# Altium schematic sheet ArcadeRpiSmartShield 33 MCU.SchDoc
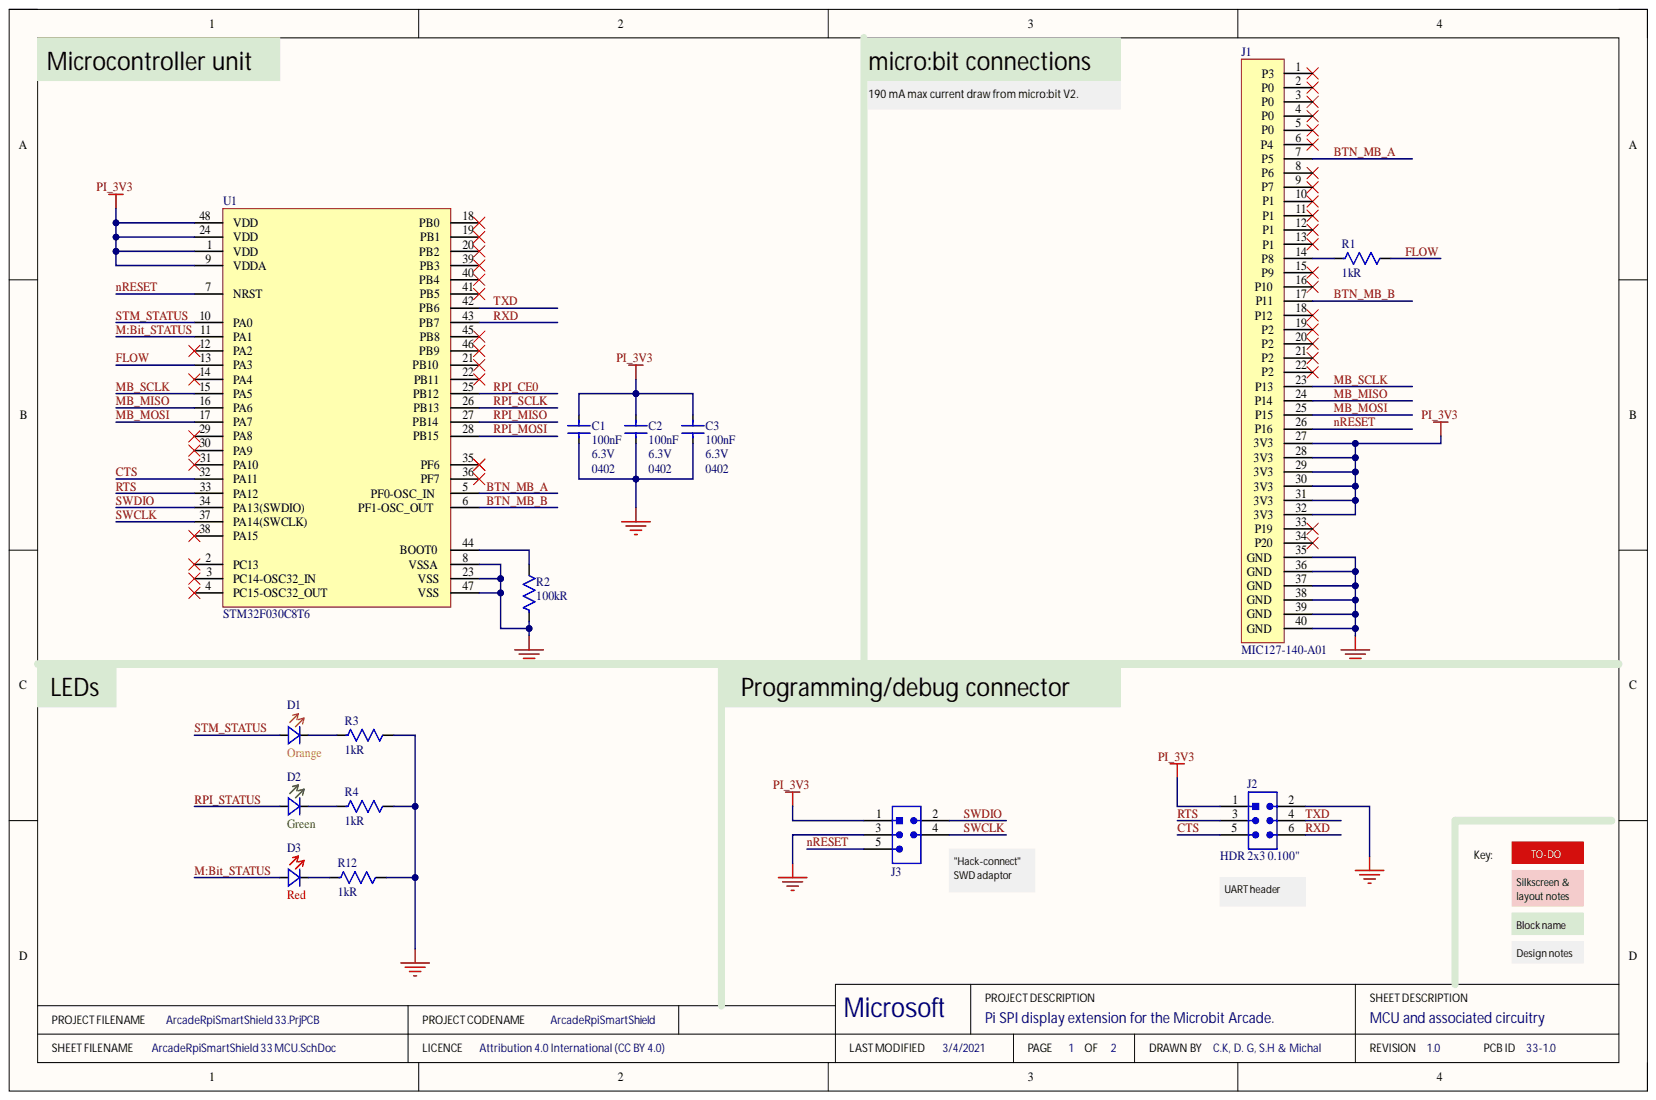
[diagram p0: ArcadeRpiSmartShield 33 MCU.SchDoc]

## Parts
C1  Capacitance: 100nF  Case Code (Imperial): 0402  Voltage Rating: 6.3V  [C0402_0.55MM - without outline]  (hidden comment: CL05B104KQ5NNNC)
C2  Capacitance: 100nF  Case Code (Imperial): 0402  Voltage Rating: 6.3V  [C0402_0.55MM - without outline]  (hidden comment: CL05B104KQ5NNNC)
C3  Capacitance: 100nF  Case Code (Imperial): 0402  Voltage Rating: 6.3V  [C0402_0.55MM - without outline]  (hidden comment: CL05B104KQ5NNNC)
D1  Colour: Orange  [Rohm SML-D12 Orange - without outline]  (hidden comment: SML-D12D)
D2  Colour: Green  [Rohm SML-D12 Green - without outline]  (hidden comment: SML-D12P)
D3  Colour: Red  [Rohm SML-D12 Red - without outline]  (hidden comment: SML-D12U)
J1  Right Angle 1x40p 1.27 mm pitch edge connector for the micro:bit  [MIC127-140-A01]  (value not drawn)
J2  HDR 2x3 0.100"  [PREC003DFAN-RC]
J3  Hack Connect Mini 1.27 mm pitch 2-row  [HACK_CONNECT_MINI_5_X_1_27]  (value not drawn)  (no BOM)
R1  Resistance: 1kR  [R0402 - Without outline]  (hidden comment: RC0402FR-071KL)
R2  Resistance: 100kR  [R0402 - Without outline]  (hidden comment: RC0402FR-07100KL)
R3  Resistance: 1kR  [R0402 - Without outline]  (hidden comment: RC0402FR-071KL)
R4  Resistance: 1kR  [R0402 - Without outline]  (hidden comment: RC0402FR-071KL)
R12  Resistance: 1kR  [R0402 - Without outline]  (hidden comment: RC0402FR-071KL)
U1  STM32F030C8T6  [48-LQFP (7x7)]

## Nets
GND: C1.1 C2.1 C3.1 J1.35(GND) J1.36(GND) J1.37(GND) J1.38(GND) J1.39(GND) J1.40(GND) J2.2 J3.3 R2.1 R3.1 R4.1 R12.1 U1.8(VSSA) U1.23(VSS) U1.47(VSS)
PI_3V3: C1.2 C2.2 C3.2 J1.27(3V3) J1.28(3V3) J1.29(3V3) J1.30(3V3) J1.31(3V3) J1.32(3V3) J2.1 J3.1 U1.1(VDD) U1.9(VDDA) U1.24(VDD) U1.48(VDD)
BTN_MB_A: J1.7(P5) U1.5(PF0-OSC_IN)
BTN_MB_B: J1.17(P11) U1.6(PF1-OSC_OUT)
CTS: J2.5 U1.32(PA11)
FLOW: R1.1 U1.13(PA3)
M:Bit_STATUS: D3.2 U1.11(PA1)
MB_MISO: J1.24(P14) U1.16(PA6)
MB_MOSI: J1.25(P15) U1.17(PA7)
MB_SCLK: J1.23(P13) U1.15(PA5)
nRESET: J1.26(P16) J3.5 U1.7(NRST)
RPI_CE0: U1.25(PB12)
RPI_MISO: U1.27(PB14)
RPI_MOSI: U1.28(PB15)
RPI_SCLK: U1.26(PB13)
RPI_STATUS: D2.2
RTS: J2.3 U1.33(PA12)
RXD: J2.6 U1.43(PB7)
STM_STATUS: D1.2 U1.10(PA0)
SWCLK: J3.4 U1.37(PA14(SWCLK))
SWDIO: J3.2 U1.34(PA13(SWDIO))
TXD: J2.4 U1.42(PB6)
NetD1_1: D1.1 R3.2
NetD2_1: D2.1 R4.2
NetD3_1: D3.1 R12.2
NetJ1_14: J1.14(P8) R1.2
NetR2_2: R2.2 U1.44(BOOT0)

Not connected: J1 1(P3) 2(P0) 3(P0) 4(P0) 5(P0) 6(P4) 8(P6) 9(P7) 10(P1) 11(P1) 12(P1) 13(P1) 15(P9) 16(P10) 18(P12) 19(P2) 20(P2) 21(P2) 22(P2) 33(P19) 34(P20); U1 2(PC13) 3(PC14-OSC32_IN) 4(PC15-OSC32_OUT) 12(PA2) 14(PA4) 18(PB0) 19(PB1) 20(PB2) 21(PB10) 22(PB11) 29(PA8) 30(PA9) 31(PA10) 35(PF6) 36(PF7) 38(PA15) 39(PB3) 40(PB4) 41(PB5) 45(PB8) 46(PB9)

## Library data of the parts
C1-C3 = CL05B104KQ5NNNC: CAP CER 0.1UF 6.3V X7R 0402; Manufacturer: Samsung; Tolerance: 10%; Case Code (Metric): 1005; Case/Package: 0402; Category: Capacitors; Component Kind: Standard; ComponentLink1Description: Online datasheet; ComponentLink1URL: https://datasheet.ciiva.com/25709/cl05b104kq5nnnc-25709268.pdf; Depth: 0.5mm; Dielectric: X7R; Height: 0.5mm; Lead Free: Lead Free; Length: 1mm; Library Name: Samsung.SchLib; Manufacturer Part Number: CL05B104KQ5NNNC; Max Operating Temperature: 125°C; Min Operating Temperature: -55°C; Mount: Surface Mount; Packaging: Tape and Reel; Pricing 1: 1=0.10000, 10=0.02500, 50=0.01380, 100=0.01130, 500=0.00804, 1000=0.00632, 2500=0.00575, 5000=0.00529 (USD); Pricing 2: 1=0.10000, 10=0.02800, 100=0.01200, 500=0.00800, 1000=0.00600, 2500=0.00600 (USD); Radiation Hardening: No; RoHS: Yes; Stock 1: 259020; Stock 2: 20000; Supplier 1: Digi-Key; Supplier 2: Mouser; Supplier Part Number 1: 1276-1511-1-ND; Supplier Part Number 2: 187-CL05B104KQ5NNNC; Thickness: 0.022inch; Voltage Rating (DC): 6.3V; Width: 0.02inch; Checked By: ?; Created By: D. Gakure
D1 = SML-D12D: 1.6 x 0.8 x 0.55mm (0603) LED 605nm Orange; Manufacturer: Rohm Semiconductor; Manufacturer Part Number: SML-D12D8WT86; Current - Test: 20mA; Lens Style/Size: Rectangle with Flat Top, 1.2mm x 0.8mm; Lens Type: Diffused, White; Millicandela Rating: 100mcd; Voltage - Forward (Vf) (Typ): 2.2V; Category: Optoelectronics; Component Kind: Standard; ComponentLink1Description: Online datasheet; ComponentLink1URL: https://d1d2qsbl8m0m72.cloudfront.net/en/products/databook/datasheet/opto/led/chip_mono/sml-d12x8_d13x8-e.pdf; Height: 0.55mm; Library Name: Rohm.SchLib; Luminous Flux @ Current - Test: -; Mounting Type: Surface Mount; Package / Case: 0603 (1608 Metric); Packaging: Cut Tape (CT); Pricing 1: 1=0.38, 10=0.279, 25=0.2232, 100=0.1478, 250=0.1046, 500=0.08926, 1000=0.06974 (GBP); RoHS: RoHS Compliant; Size / Dimension: 1.60mm L x 0.80mm W; Stock 1: 1719; Supplier 1: Digi-Key; Supplier Part Number 1: 511-1577-1-ND; Viewing Angle: -; Wavelength - Dominant: 605nm; Wavelength - Peak: -
D2 = SML-D12P: 1.6 x 0.8 x 0.55mm (0603) LED 560nm Green; Manufacturer: Rohm Semiconductor; Manufacturer Part Number: SML-D12P8WT86; Current - Test: 20mA; Lens Style/Size: Rectangle with Flat Top, 1.2mm x 0.8mm; Lens Type: Diffused, White; Millicandela Rating: 6.3mcd; Voltage - Forward (Vf) (Typ): 2.2V; Category: Optoelectronics; Component Kind: Standard; ComponentLink1Description: Online datasheet; ComponentLink1URL: https://d1d2qsbl8m0m72.cloudfront.net/en/products/databook/datasheet/opto/led/chip_mono/sml-d12x8_d13x8-e.pdf; Height: 0.55mm; Library Name: Rohm.SchLib; Luminous Flux @ Current - Test: -; Mounting Type: Surface Mount; Package / Case: 0603 (1608 Metric); Packaging: Cut Tape (CT); Pricing 1: 1=0.38, 10=0.279, 25=0.2232, 100=0.1478, 250=0.1046, 500=0.08926, 1000=0.06974 (GBP); RoHS: RoHS Compliant; Size / Dimension: 1.60mm L x 0.80mm W; Stock 1: 19305; Supplier 1: Digi-Key; Supplier Part Number 1: 511-1579-1-ND; Viewing Angle: -; Wavelength - Dominant: 560nm; Wavelength - Peak: -
D3 = SML-D12U: 1.6 x 0.8 x 0.55mm (0603) LED 620nm Red; Manufacturer: Rohm Semiconductor; Manufacturer Part Number: SML-D12U8WT86; Current - Test: 20mA; Lens Style/Size: Rectangle with Flat Top, 1.2mm x 0.8mm; Lens Type: Diffused, White; Millicandela Rating: 63mcd; Voltage - Forward (Vf) (Typ): 2.2V; Category: Optoelectronics; Component Kind: Standard; ComponentLink1Description: Online datasheet; ComponentLink1URL: https://d1d2qsbl8m0m72.cloudfront.net/en/products/databook/datasheet/opto/led/chip_mono/sml-d12x8_d13x8-e.pdf; Height: 0.55mm; Library Name: Rohm.SchLib; Luminous Flux @ Current - Test: -; Mounting Type: Surface Mount; Package / Case: 0603 (1608 Metric); Packaging: Cut Tape (CT); Pricing 1: 1=0.38, 10=0.279, 25=0.2232, 100=0.1478, 250=0.1046, 500=0.08926, 1000=0.06974 (GBP); RoHS: RoHS Compliant; Size / Dimension: 1.60mm L x 0.80mm W; Stock 1: 5722; Supplier 1: Digi-Key; Supplier 2: Farnell; Supplier Part Number 1: 511-1580-1-ND; Supplier Part Number 2: 1685094; Viewing Angle: -; Wavelength - Dominant: 620nm; Wavelength - Peak: -
J1 = MIC127-140-A01: "Right Angle" 1x40p 1.27mm Dongguan Yuliang BBC micro:bit edge connector; Component Kind: Standard; ComponentLink1Description: Yuliang drawing; ComponentLink1URL: http://www.dgyuliang.net/d/file/Produtcs/Customized%20Connector/MICRO%20BIT%20Connector/0d43030af84ade6fc3f00e242079c055.pdf; Library Name: Yuliang.SchLib; Manufacturer: Dongguan Yuliang; Manufacturer Part Number: MIC127-140-A01
J2 = PREC003DFAN-RC: CONN HEADER VERT 6POS 2.54MM; Manufacturer: Sullins; Connector Type: Male Pin; Number of Positions: 6; Pitch: 0.1inch; Category: Connectors; Color: Black; Component Kind: Standard; ComponentLink1Description: Online datasheet; ComponentLink1URL: https://datasheet.ciiva.com/741/xrxczzzdxxn-rc-11636-b-741002.pdf; Contact Mating Length: 0.318inch; Contact Plating: Tin; Contact Type: Male Pin; Library Name: SullinsConnectorSolutions.SchLib; Manufacturer Part Number: PREC003DFAN-RC; Mount: Through Hole; Number of Rows: 2; Package Quantity: 1; Packaging: Bulk; Pricing 1: 1=0.16000, 10=0.15100, 25=0.12920, 50=0.11280, 100=0.10480, 250=0.09272, 500=0.08870 (USD); RoHS: Yes; RoHS Compliant: Yes; Row Spacing: 0.1inch; Stock 1: 1243; Supplier 1: Digi-Key; Supplier Part Number 1: S2212EC-03-ND; Termination: Solder; Checked By: ?; Created By: D. Gakure
J3 = HACK_CONNECT_MINI: Description: Hack Connect Mini 1.27 mm pitch 2-row; Library Name: generic-con.SchLib
R1 R3 R4 R12 = RC0402FR-071KL: RES SMD 1K OHM 1% 1/16W 0402; Manufacturer: Yageo; Power Rating: 62.5mW; Temperature Coefficient: 100ppm/°C; Voltage Rating: 50V; Case Code (Imperial): 0402; Case Code (Metric): 1005; Case/Package: 0402; Category: Resistors; Component Kind: Standard; ComponentLink2Description: Online datasheet; ComponentLink2URL: https://datasheet.ciiva.com/12666/pyu-rc0402-51-rohs-l-6-r-12666741.pdf; Depth: 0.5mm; Height: 0.32mm; Lead Free: Lead Free; Length: 1mm; Library Name: Yageo.SchLib; Manufacturer Part Number: RC0402FR-071KL; Max Operating Temperature: 155°C; Military Standard: Not; Min Operating Temperature: -55°C; Number of Pins: 2; Packaging: Tape and Reel; Pricing 1: 1=0.10000, 10=0.01400, 100=0.00580, 1000=0.00262, 2500=0.00228, 5000=0.00188 (USD); Pricing 2: 1=0.10000, 10=0.01200, 100=0.00400, 1000=0.00400, 2500=0.00400, 10000=0.00300 (USD); Pricing 3: 1=0.08130, 25=0.01210, 100=0.01120, 250=0.01030, 500=0.00900 (GBP); REACH SVHC: Unknown; Resistor Type: Thick Film; RoHS: Yes; Stock 1: 37591306; Stock 2: 11912978; Stock 3: 29900; Supplier 1: Digi-Key; Supplier 2: Mouser; Supplier 3: Farnell; Supplier Part Number 1: 311-1.00KLRDKR-ND; Supplier Part Number 2: 603-RC0402FR-071KL; Supplier Part Number 3: 2132441; Termination: SMD/SMT; Tolerance: 1%; Voltage Rating (DC): 50V; Width: 0.5mm; Checked: ?; Created: D.G
R2 = RC0402FR-07100KL: RES SMD 100K OHM 1% 1/16W 0402; Manufacturer: Yageo; Max Power Dissipation: 63mW; Temperature Coefficient: 100ppm/°C; Voltage Rating: 50V; Case Code (Imperial): 0402; Case Code (Metric): 1005; Case/Package: 0402; Category: Resistors; Component Kind: Standard; ComponentLink2Description: Online datasheet; ComponentLink2URL: https://datasheet.ciiva.com/2453/pyu-r-int-thick-7-2453361.pdf; Depth: 0.5mm; Features: Moisture Resistant; Height: 0.016inch; Lead Free: Lead Free; Length: 0.039inch; Library Name: Yageo.SchLib; Manufacturer Part Number: RC0402FR-07100KL; Max Operating Temperature: 155°C; Military Standard: Not; Min Operating Temperature: -55°C; Number of Terminations: 2; Package Quantity: 1; Packaging: Tape and Reel; Power Rating: 63mW; Pricing 1: 1=0.10000, 10=0.01400, 100=0.00580, 1000=0.00262, 2500=0.00228, 5000=0.00188 (USD); Pricing 2: 1=0.10000, 10=0.01200, 100=0.00400, 1000=0.00400, 2500=0.00400, 10000=0.00300 (USD); Pricing 3: 1=0.10200, 25=0.01220, 100=0.01200, 250=0.01020, 500=0.00890 (GBP); REACH SVHC: No SVHC; Resistor Type: Thick Film; RoHS: Yes; Stock 1: 5819191; Stock 2: 4458847; Stock 3: 19392; Supplier 1: Digi-Key; Supplier 2: Mouser; Supplier 3: Farnell; Supplier Part Number 1: 311-100KLRCT-ND; Supplier Part Number 2: 603-RC0402FR-07100KL; Supplier Part Number 3: 2131798; Termination: SMD/SMT; Tolerance: 1%; Width: 0.02inch; Working Voltage: 50V; Checked By: ?; Created By: DG
U1 = STM32F030C8T6: MCU 32-bit STM32F ARM Cortex M0 RISC 64KB Flash 2.5V/3.3V 48-Pin LQFP Tray; Manufacturer: STMicroelectronics; Data Bus Width: 32b; Frequency: 48MHz; Interface: I2C,SPI,UART,USART; Max Power Dissipation: 364mW; Max Supply Voltage: 3.6V; Min Supply Voltage: 2.4V; Number of ADC Channels: 12; Number of I2C Channels: 2; Number of I/Os: 39; Number of PWM Channels: 6; Number of Timers/Counters: 7; Oscillator Type: Internal; Peripherals: POR,PWM,WDT,DMA; RAM Size: 8KB; Watchdog Timer: Yes; Case/Package: LQFP; Category: Microcontrollers; Component Kind: Standard; ComponentLink1Description: Online datasheet; ComponentLink1URL: https://datasheet.ciiva.com/28647/1792126-28647793.pdf; Contact Plating: Tin,Matte; Core Architecture: ARM; Height: 1.45mm; Lead Free: Lead Free; Length: 7.2mm; Library Name: ST.SchLib; Manufacturer Part Number: STM32F030C8T6; Max Frequency: 48MHz; Max Operating Temperature: 85°C; Memory Size: 64KB; Memory Type: FLASH; Min Operating Temperature: -40°C; Number of Pins: 48; Number of Programmable I/O: 39; Number of SPI Channels: 2; Package Quantity: 250; Packaging: Tray; Pricing 1: 1=2.31000, 10=2.07200, 25=1.95520, 80=1.66575, 250=1.56408, 500=1.36856, 1000=1.30340 (USD); Pricing 2: 1=2.19000, 10=1.88000, 100=1.63000, 500=1.38000, 1000=1.16000, 2500=1.13000 (USD); Radiation Hardening: No; REACH SVHC: No SVHC; RoHS: Yes; RoHS Compliant: Yes; Stock 2: 325; Supplier 1: Digi-Key; Supplier 2: Avnet; Supplier Part Number 1: 497-14043-ND; Supplier Part Number 2: 39X0182; Width: 7.2mm; Checked By: ?; Created By: D. Gakure
